# Altium schematic sheet Debug.SchDoc(Debug)
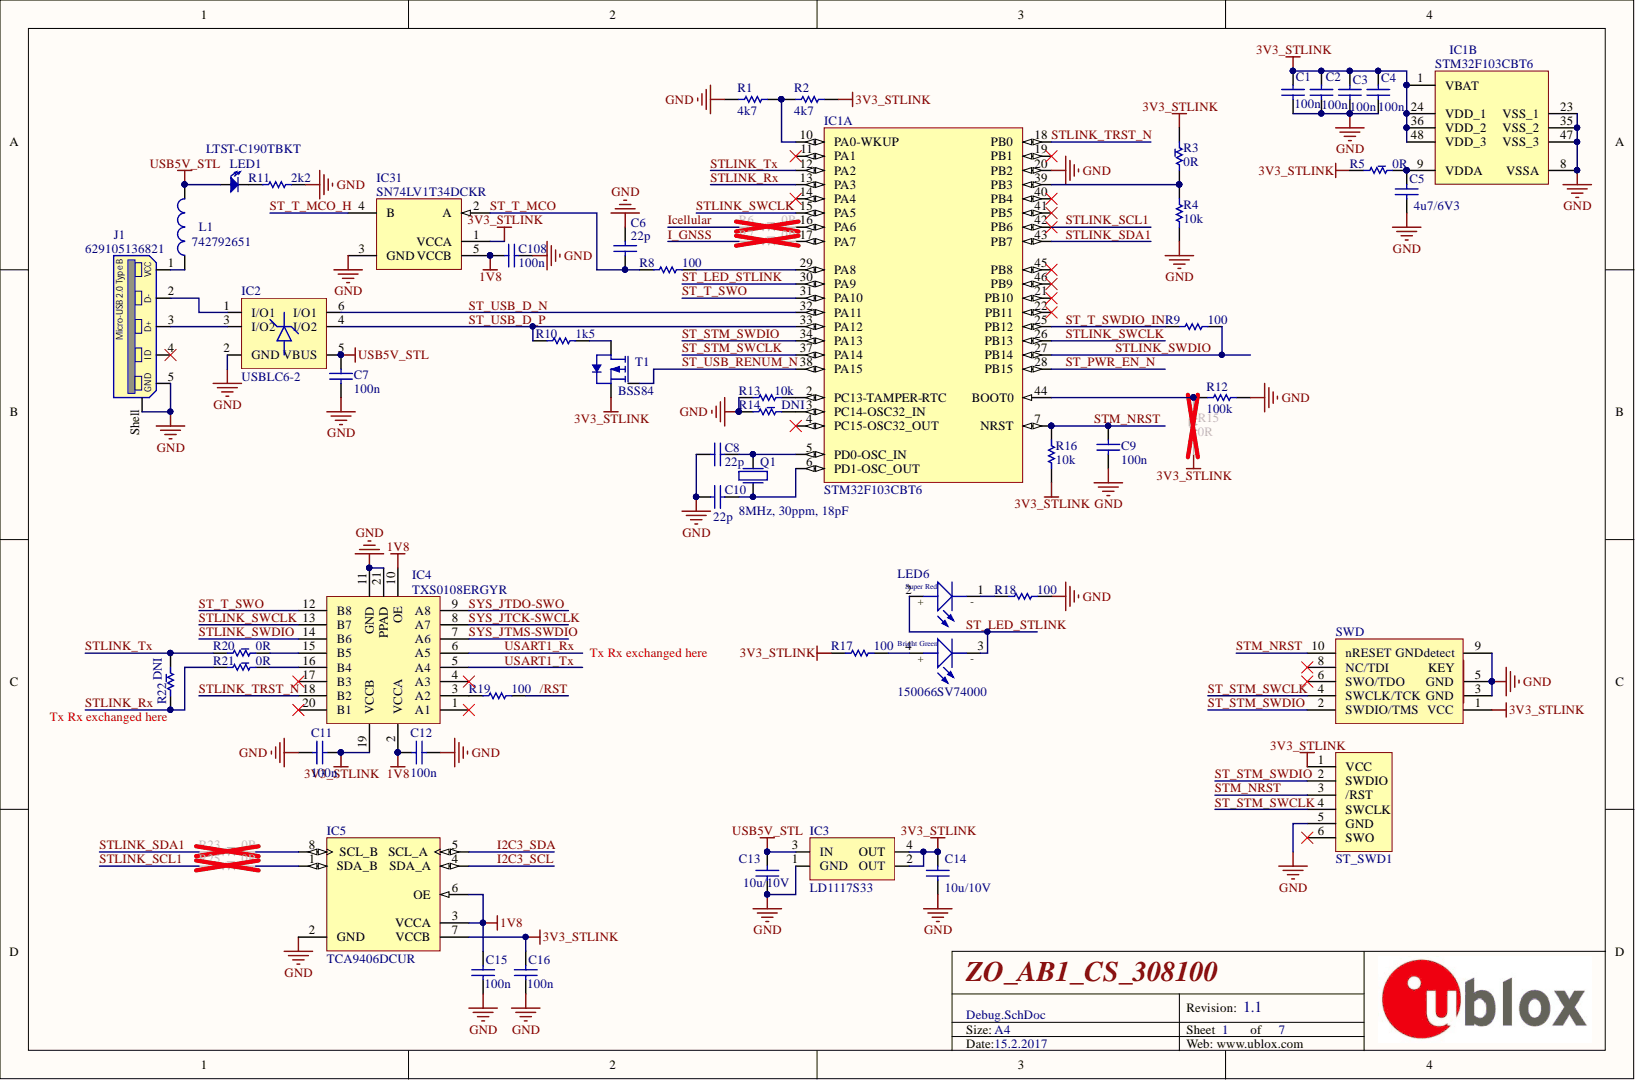
[diagram p0: Debug.SchDoc]

## Parts
C1  100n  [C0402]
C2  100n  [C0402]
C3  100n  [C0402]
C4  100n  [C0402]
C5  4u7/6V3  [C0402]
C6  22p  [C0402]
C7  100n  [C0402]
C8  22p  [C0402]
C9  100n  [C0402]
C10  22p  [C0402]
C11  100n  [C0402]
C12  100n  [C0402]
C13  10u/10V  [C0805]
C14  10u/10V  [C0805]
C15  100n  [C0402]
C16  100n  [C0402]
C108  100n  [C0402]
IC1  STM32F103CBT6  [STM-LQFP48_L]  (parts A,B)
IC2  USBLC6-2  [SOT23-6L]
IC3  LD1117S33  [SOT223]
IC4  TXS0108ERGYR  [RGY QFN50P450X350X100_HS-21L]
IC5  TCA9406DCUR  [DCU8_L]
IC31  SN74LV1T34DCKR  [DCK0005A_L]
J1  629105136821  [629105136821]
L1  742792651  [L0603]
LED1  LTST-C190TBKT  [LED0603 - BLUE]
LED6  150066SV74000  [WL-SBCW 0606]
Q1  8MHz, 30ppm, 18pF  [HC49SM-L]
R1  4k7  [R0402]
R2  4k7  [R0402]
R3  0R  [R0603pacman]
R4  10k  [R0402]
R5  0R  [R0603pacman]
R6  0R  [R0603pacman]
R7  0R  [R0603pacman]
R8  100  [R0402]
R9  100  [R0402]
R10  1k5  [R0402]
R11  2k2  [R0402]
R12  100k  [R0402]
R13  10k  [R0402]
R14  DNI  [R0603pacman]
R15  0R  [R0603pacman]
R16  10k  [R0402]
R17  100  [R0402]
R18  100  [R0402]
R19  100  [R0402]
R20  0R  [R0603pacman]
R21  0R  [R0603pacman]
R22  DNI  [R0603pacman]
R23  0R  [R0603pacman]
R25  0R  [R0603pacman]
ST_SWD1  TC2030-CTL-NL  [TC2030-MCP-NL]  (value not drawn)  (no BOM)
SWD  FTSH-105-01 with missing pin7 - same as on MCBs  [SWD10 pin-7]  (value not drawn)
T1  BSS84  [SOT23_L]

## Nets
1V8: C12.2 C15.2 C108.2 IC4.2(VCCA) IC4.10(OE) IC5.3(VCCA) IC5.6(OE) IC31.5(VCCB)
3V3_STLINK: C1.1 C2.1 C3.1 C4.1 C11.1 C14.2 C16.2 IC1.1(VBAT) IC1.24(VDD_1) IC1.36(VDD_2) IC1.48(VDD_3) IC3.2(OUT) IC3.4(OUT) IC4.19(VCCB) IC5.7(VCCB) IC31.1(VCCA) R2.1 R3.2 R5.1 R15.1 R16.2 R17.2 ST_SWD1.1(VCC) SWD.1(VCC) T1.2
GND: C1.2 C2.2 C3.2 C4.2 C5.2 C6.2 C7.1 C8.1 C9.1 C10.1 C11.2 C12.1 C13.1 C14.1 C15.1 C16.1 C108.1 IC1.8(VSSA) IC1.20(PB2) IC1.23(VSS_1) IC1.35(VSS_2) IC1.47(VSS_3) IC2.2(GND) IC3.1(GND) IC4.11(GND) IC4.21(PPAD) IC5.2(GND) IC31.3(GND) J1.5 J1.Shell R1.2 R4.2 R11.2 R12.1 R13.1 R14.1 R18.1 ST_SWD1.5(GND) SWD.3(GND) SWD.5(GND) SWD.9(GNDdetect)
USB5V_STL: C7.2 C13.2 IC2.5(VBUS) IC3.3(IN) L1.2 LED1.A
/RST: R19.1
I2C3_SCL: IC5.4(SDA_A)
I2C3_SDA: IC5.5(SCL_A)
I_GNSS: R7.1
ICELLULAR: R6.1
ST_LED_STLINK: IC1.30(PA9) LED6.2 LED6.3
ST_PWR_EN_N: IC1.28(PB15)
ST_STM_SWCLK: IC1.37(PA14) ST_SWD1.4(SWCLK) SWD.4(SWCLK/TCK)
ST_STM_SWDIO: IC1.34(PA13) ST_SWD1.2(SWDIO) SWD.2(SWDIO/TMS)
ST_T_MCO: C6.1 IC31.2(A) R8.2
ST_T_MCO_H: IC31.4(B)
ST_T_SWDIO_IN: IC1.25(PB12) R9.2
ST_T_SWO: IC1.31(PA10) IC4.12(B8)
ST_USB_D_N: IC1.32(PA11) IC2.6(I/O1)
ST_USB_D_P: IC1.33(PA12) IC2.4(I/O2) R10.2
ST_USB_RENUM_N: IC1.38(PA15) T1.1
STLINK_RX: IC1.13(PA3) R21.1 R22.1
STLINK_SCL1: IC1.42(PB6) R25.1
STLINK_SDA1: IC1.43(PB7) R23.1
STLINK_SWCLK: IC1.15(PA5) IC1.26(PB13) IC4.13(B7)
STLINK_SWDIO: IC1.27(PB14) IC4.14(B6) R9.1
STLINK_TRST_N: IC1.18(PB0) IC4.18(B2)
STLINK_TX: IC1.12(PA2) R20.1 R22.2
STM_NRST: C9.2 IC1.7(NRST) R16.1 ST_SWD1.3(/RST) SWD.10(nRESET)
SYS_JTCK-SWCLK: IC4.8(A7)
SYS_JTDO-SWO: IC4.9(A8)
SYS_JTMS-SWDIO: IC4.7(A6)
USART1_RX: IC4.6(A5)
USART1_TX: IC4.5(A4)
NetC5_1: C5.1 IC1.9(VDDA) R5.2
NetC8_2: C8.2 IC1.5(PD0-OSC_IN) Q1.2
NetC10_2: C10.2 IC1.6(PD1-OSC_OUT) Q1.1
NetIC1_2: IC1.2(PC13-TAMPER-RTC) R13.2
NetIC1_3: IC1.3(PC14-OSC32_IN) R14.2
NetIC1_10: IC1.10(PA0-WKUP) R1.1 R2.2
NetIC1_16: IC1.16(PA6) R6.2
NetIC1_17: IC1.17(PA7) R7.2
NetIC1_29: IC1.29(PA8) R8.1
NetIC1_39: IC1.39(PB3) R3.1 R4.1
NetIC1_44: IC1.44(BOOT0) R12.2 R15.2
NetIC2_1: IC2.1(I/O1) J1.2(D-)
NetIC2_3: IC2.3(I/O2) J1.3(D+)
NetIC4_3: IC4.3(A2) R19.2
NetIC4_15: IC4.15(B5) R20.2
NetIC4_16: IC4.16(B4) R21.2
NetIC5_1: IC5.1(SDA_B) R25.2
NetIC5_8: IC5.8(SCL_B) R23.2
NetJ1_1: J1.1 L1.1
NetLED1_K: LED1.K R11.1
NetLED6_1: LED6.1 R18.2
NetLED6_4: LED6.4 R17.1
NetR10_1: R10.1 T1.3

Not connected: IC1 4(PC15-OSC32_OUT) 11(PA1) 14(PA4) 19(PB1) 21(PB10) 22(PB11) 40(PB4) 41(PB5) 45(PB8) 46(PB9); IC4 1(A1) 4(A3) 17(B3) 20(B1); J1 4(ID); ST_SWD1 6(SWO); SWD 6(SWO/TDO) 8(NC/TDI)

## Library data of the parts
C1-C16 C108 = Capacitor SMD: SMD chip capacitor; Value: Xn; Component Kind: Standard; LatestRevisionDate: 26-Dec-2008; Published: 26-Dec-2008; Signal Integrity: Cap; Simulation: CAP; Library Name: BorisPassive.SchLib; Publisher: www.poscope.com
IC1 = STM32F103CBT6: ARM Cortex-M3 32-bit MCU, 128 KB Flash, 20 KB Internal RAM, 37 I/Os, 48-pin LQFP, -40 to 85 degC, Tray; A/D Converter: 2x12-bit (10 Channel); FLASH Size (Prog) (kB): 128; I/Os (High Current): 37; Internal RAM Size (kB): 20; Operating Frequency(F) (Processor speed) (MHz): 72; Supply Voltage(Vcc) min (V): 2; Component Kind: Standard; ComponentLink1Description: Manufacturer URL; ComponentLink1URL: http://www.st.com/web/en/home.html; ComponentLink2Description: Datasheet; ComponentLink2URL: http://www.st.com/st-web-ui/static/active/en/resource/technical/document/datasheet/CD00161566.pdf; ComponentLink3Description: Package Specification; ComponentLink3URL: http://www.st.com/st-web-ui/static/active/en/resource/technical/document/datasheet/DM00058181.pdf; Core: ARM Cortex-M3; DatasheetVersion: Rev. 16; Library Name: STMicroelectronics STM32 F1.IntLib; Mounting Technology: Surface Mount; PackageDescription: 48-Pin Low Profile Quad Flat Package, 7 x 7 mm Body, 0.5 mm Pitch; PackageReference: LQFP48; PackageVersion: Rev. 6, 06/2013; Packing: Tray; PartNumber: STM32F103CBT6; Supplier 1: Digi-Key; Supplier 2: Farnell; Supplier 3: Newark; Supplier 4: Newark; Supplier 5: Mouser; Supplier Part Number 1: 497-6288-ND; Supplier Part Number 2: 1606327; Supplier Part Number 3: 11N8296; Supplier Part Number 4: 57P1214; Supplier Part Number 5: 511-STM32F103CBT6
IC2 = USBLC6-2: Very low capacitance ESD protection; Component Kind: Standard; Library Name: BorisIC1.SchLib
IC3 = LD1117: 800mA LDO linear regulator; Component Kind: Standard; Library Name: BorisIC1.SchLib
IC4 = TXS0108ERGYR: 8-Bit Bi-directional, Level-Shifting, Voltage Translator  for Open-Drain and Push-Pull Applications; Component Kind: Standard; Library Name: BorisIC1.SchLib
IC5 = TCA9406DCUR: TCA9406 Dual Bidirectional 1-MHz I2C-BUS and SMBus Voltage Level-Translator, 1.65 to 3.6 V, -40 to 85 degC, 8-pin US8 (DCU), Green (RoHS & no Sb/Br); Manufacturer: Texas Instruments; 5V Tolerant I/O: Yes; Function: I2C Voltage Level Translator; Max Frequency(kHz): 1000; No. of Outputs: 2; Rating: Catalog; SubFamily: I2C Special Function; VCC(Max)(V): 4.6; VCC(Min)(V): -0.5; Code_JEDEC: MO-187-CA; Component Kind: Standard; ComponentLink1Description: Datasheet; ComponentLink1URL: http://www.ti.com/general/docs/lit/getliterature.tsp?genericPartNumber=TCA9406&fileType=pdf; ComponentLink2Description: Package Specification; ComponentLink2URL: http://www.ti.com/litv/pdf/mpds050d; DatasheetVersion: SCPS221; Eco-Plan: Green (RoHS & no Sb/Br); Enable Pin: Yes; Library Name: Texas Instruments Interface I2C.IntLib; Mounting Technology: Surface Mount; On-Chip Power-on-Reset: No; Open Drain I/O Type: Yes; PackageDescription: 8-Pin Thin Shrink Small Outline Package 0.5 mm Pitch; PackageReference: DCU8; PackageVersion: revF, Jul-2005; PartNumber: TCA9406DCUR; Push-Pull I/O Type: Yes; Supplier 1: Digi-Key; Supplier 2: Digi-Key; Supplier 3: Digi-Key; Supplier 4: Farnell; Supplier 5: Newark; Supplier 6: Newark; Supplier 7: Mouser; Supplier Part Number 1: 296-28109-2-ND; Supplier Part Number 2: 296-28109-1-ND; Supplier Part Number 3: 296-28109-6-ND; Supplier Part Number 4: 1972385; Supplier Part Number 5: 59T0098; Supplier Part Number 6: 24T4760; Supplier Part Number 7: 595-TCA9406DCUR; Vcc range(V): 1.65 to 3.6
IC31 = SN74AUP1T34DCKR: 1-Bit Unidirectional Voltage-Level Translator, DCK0005A, LARGE T&R; Manufacturer: Texas Instruments; 3-State Output: No; Bits(#): 1; F @ Nom Voltage(Max)(Mhz): 190; ICC @ Nom Voltage(Max)(mA): 0.0009; Input Type: CMOS; Logic: True; Output Drive (IOL/IOH)(Max)(mA): 4/-4; Rating: Catalog; Sub-Family: Dual Supply Translator; Technology Family: AUP1T; tpd @ Nom Voltage(Max)(ns): 5.48,4.72,4.28; VCC(Max)(V): 3.6; VCC(Min)(V): 0.8; Voltage(Nom)(V): 1.8,2.5,3.3; Component Kind: Standard; ComponentLink1Description: Manufacturer URL; ComponentLink1URL: http://www.ti.com/; ComponentLink2Description: Datasheet; ComponentLink2URL: http://www.ti.com/lit/ds/symlink/sn74aup1t34.pdf; DatasheetVersion: SCES841C; Generic Part Number: SN74AUP1T34; Library Name: TI_Logic_Little_Logic.IntLib; Operating Temperature Range(C): -40 to 85; Output Type: CMOS; PackageReference: DCK0005A; PartNumber: SN74AUP1T34DCKR; Schmitt Trigger: No; Supplier 1: Mouser; Supplier 2: Digi-Key; Supplier 3: Digi-Key; Supplier 4: Digi-Key; Supplier Part Number 1: 595-SN74AUP1T34DCKR; Supplier Part Number 2: 296-34900-2-ND; Supplier Part Number 3: 296-34900-1-ND; Supplier Part Number 4: 296-34900-6-ND
J1 = 629105136821: WR-COM Micro USB 2.0 SMT Type B Horizontal 5 Contacts; Component Kind: Standard; ComponentLink1Description: Manufacturer; ComponentLink1URL: http://www.we-online.de; ComponentLink2Description: Datasheet; ComponentLink2URL: http://katalog.we-online.de/em/datasheet/629105136821.pdf; Library Name: ALTIUM-WR-COM-rev16d.IntLib; PartNumber: 629105136821; Published: June 2016; Publisher: Wurth Elektronik
L1 = Inductor SMD: Value: XuH; Component Kind: Standard; LatestRevisionDate: 21 March 2009; Published: 21 March 2009; Library Name: BorisPassive.SchLib; Publisher: www.poscope.com
LED1 = LEDmini: Component Kind: Standard; Description: LEDmini; Library Name: BorisSemi.SchLib
LED6 = WL-SBCW 0606, 150066SV74000: WL-SBCW SMD chip LED top view bi-color waterclear, 0606; Manufacturer: Wurth Elektronik; Color: Super Red & Bright Green; Component Kind: Standard; ComponentLink1Description: Manufacturer; ComponentLink1URL: http://www.we-online.de; ComponentLink2Description: Datasheet; ComponentLink2URL: http://katalog.we-online.de/led/datasheet/150066SV74000.pdf; Created Date: March 2016; Library Name: ALTIUM-WL-SBCW-rev16a.IntLib; PartNo: 150066SV74000; Created by: Baojun Chen
Q1 = Crystal HC49: Component Kind: Standard; Description: Crystal HC49; Library Name: BorisPassive.SchLib
R1 R2 R4 R8-R13 R16-R19 = Resistor SMD: SMD chip resistor; Value: Xk; Component Kind: Standard; LatestRevisionDate: 26-Dec-2008; Published: 26-Dec-2008; Signal Integrity: Res Semi; Simulation: RES; Library Name: BorisPassive.SchLib; Publisher: www.poscope.com
R3 R5-R7 R14 R15 R20-R23 R25 = Resistor Pacman SMD: SMD chip resistor with Payman for easy soldering; Value: Xk; Component Kind: Standard; LatestRevisionDate: 26-Dec-2008; Published: 26-Dec-2008; Signal Integrity: Res Semi; Simulation: RES; Library Name: BorisPassive.SchLib; Publisher: www.poscope.com
ST_SWD1 = CortexSWD: Tag Connect programming adapter footprint; Library Name: BorisConProg.SchLib
SWD = SWD 10pin-7: SW Debug connector, 10 pole, vertical, Male, missing pin 7; Samtec: FTSH-105-01-L-DV-K; Component Kind: Standard; Library Name: BorisConProg.SchLib; Publisher: Boris
T1 = BSS84: P-channel enhancement mode vertical DMOS transistor; Component Kind: Standard; datasheet: http://www.nxp.com/documents/data_sheet/BSS84.pdf; Library Name: BorisSemi.SchLib
